# Altium schematic sheet Alimentation.SchDoc
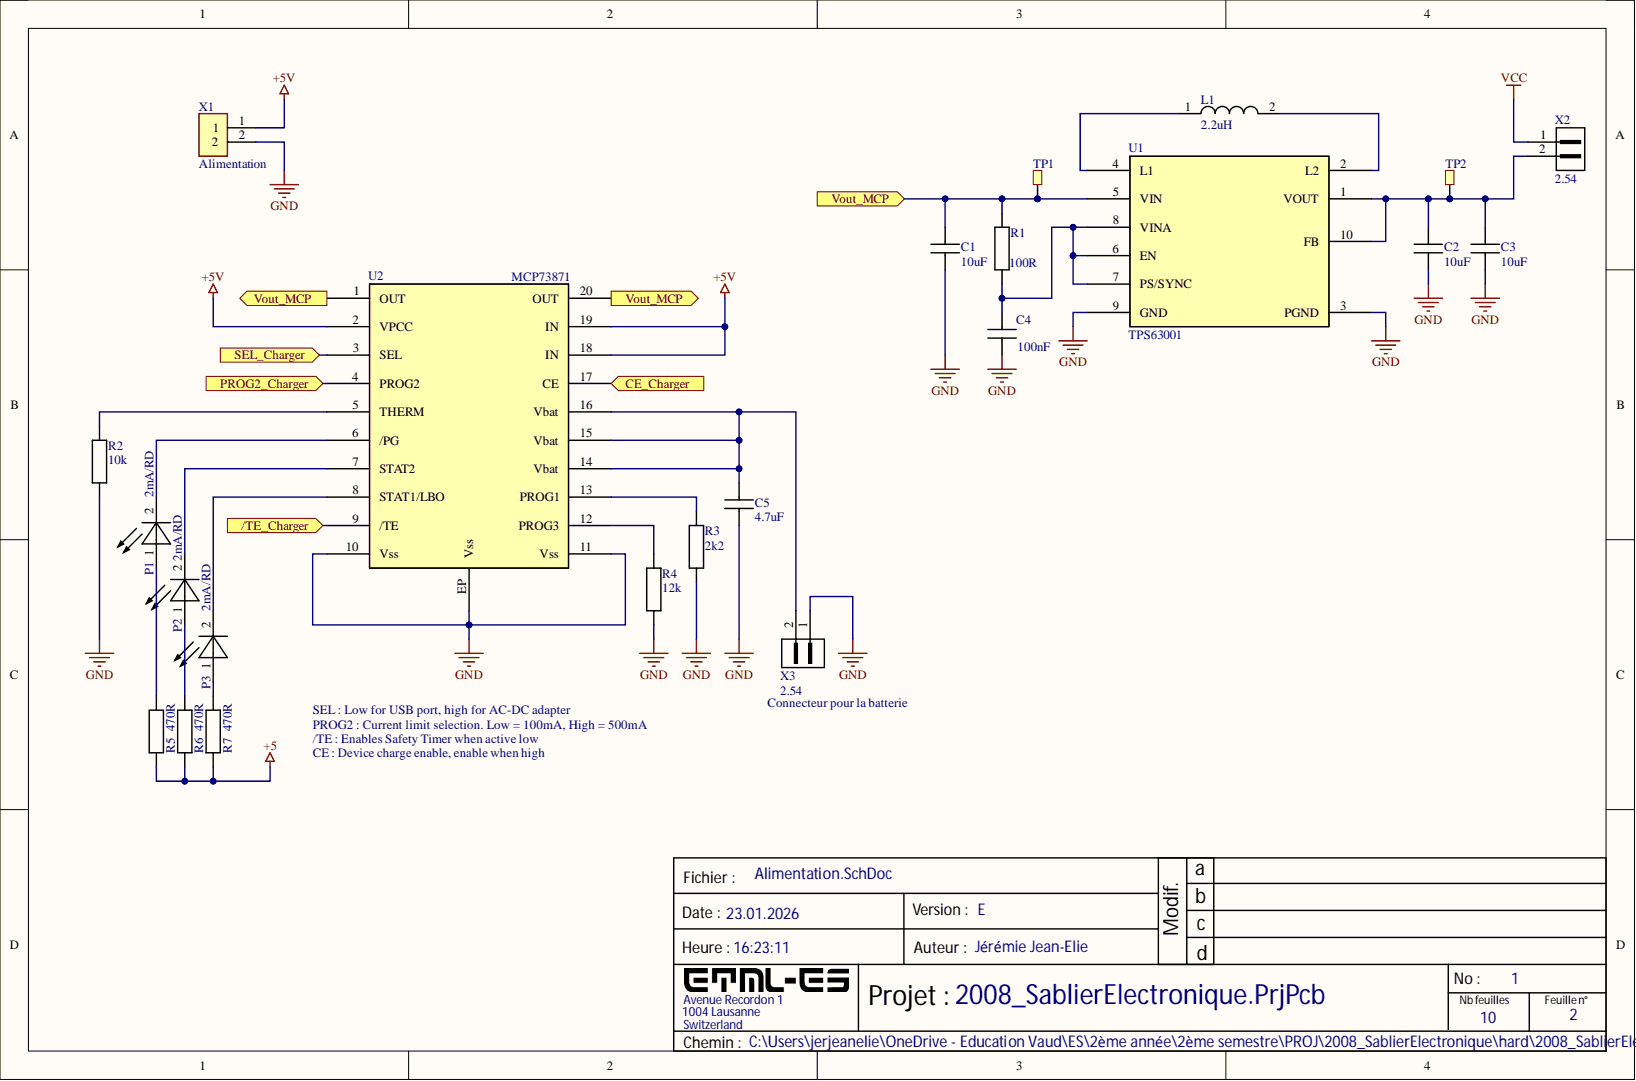
[diagram p0: Alimentation.SchDoc]

## Parts
C1  10uF  [CAPC1608X08M]
C2  10uF  [CAPC1608X08M]
C3  10uF  [CAPC1608X08M]
C4  100nF  [CAPC1608X08M]
C5  4.7uF  [CAPC1608X08M]
L1  2.2uH
P1  2mA/RD  [LED-PLCC2]
P2  2mA/RD  [LED-PLCC2]
P3  2mA/RD  [LED-PLCC2]
R1  100R  [RESC1608X06M]
R2  10k  [RESC1608X06M]
R3  2k2  [RESC1608X06M]
R4  12k  [RESC1608X06M]
R5  470R  [RESC1608X06M]
R6  470R  [RESC1608X06M]
R7  470R  [RESC1608X06M]
TP1  5019  [TESTPOINT_SMD]  (value not drawn)
TP2  5019  [TESTPOINT_SMD]  (value not drawn)
U1  TPS63001
U2  MCP73871
X1  Alimentation  [Header 2 pin]
X2  2.54  [PIN-1x02-2.54]
X3  2.54  [PIN-1x02-2.54]

## Ports
/TE_Charger, CE_Charger, PROG2_Charger, SEL_Charger, Vout_MCP

## Nets
+5: R5.1 R6.1 R7.1
+5V: U2.2(VPCC) U2.18(IN) U2.19(IN) X1.1
GND: C1.1 C2.1 C3.1 C4.1 C5.1 R2.1 R3.1 R4.1 U1.3(PGND) U1.9(GND) U2.10(Vss) U2.11(Vss) U2.EP(Vss) X1.2 X3.1
VCC: X2.1
/TE_Charger: U2.9(/TE) ; port /TE_Charger
CE_Charger: U2.17(CE) ; port CE_Charger
PROG2_Charger: U2.4(PROG2) ; port PROG2_Charger
SEL_Charger: U2.3(SEL) ; port SEL_Charger
Vout_MCP: C1.2 R1.2 TP1.1 U1.5(VIN) U2.1(OUT) U2.20(OUT) ; port Vout_MCP
NetC2_2: C2.2 C3.2 TP2.1 U1.1(VOUT) U1.10(FB) X2.2
NetC4_2: C4.2 R1.1 U1.6(EN) U1.7(PS/SYNC) U1.8(VINA)
NetC5_2: C5.2 U2.14(Vbat) U2.15(Vbat) U2.16(Vbat) X3.2
NetL1_1: L1.1 U1.4(L1)
NetL1_2: L1.2 U1.2(L2)
NetP1_1: P1.1 R5.2
NetP1_2: P1.2 U2.6(/PG)
NetP2_1: P2.1 R6.2
NetP2_2: P2.2 U2.7(STAT2)
NetP3_1: P3.1 R7.2
NetP3_2: P3.2 U2.8(STAT1/LBO)
NetR2_2: R2.2 U2.5(THERM)
NetR3_2: R3.2 U2.13(PROG1)
NetR4_2: R4.2 U2.12(PROG3)

## Library data of the parts
C1-C3 = CMP-065-000003-2: CAPC 10uF X5R 10V 20% 1608 (0603 INCH); Manufacturer: TDK; Manufacturer Number: C1608X5R1A106M080AC; IsStocked: 1; PN: PN-131657; TemperatureRange: -55°C to +85°C; Value: 10µF; Category: Passives; Component Kind: Standard; Dielectric: X5R; Library Name: ETML-ES; SubCategory: Condensateurs ceramique; Supplier 1: ETML; Supplier Part Number 1: FAB.0603_C 10uF; Supplier Price 1: 0.0944; Tolerance: ±20%; Voltage: 10V
C4 = CMP-065-000000-2: CAPC 100nF X7R 50V 10% 1608 (0603 INCH); Manufacturer: TDK; Manufacturer Number: CGA3E2X7R1H104K080AA; Current: NULL; IsStocked: 1; PN: PN-338083; TemperatureRange: -55°C to +125°C; Category: Passives; Component Kind: Standard; Dielectric: X7R; Library Name: ETML-ES; Power: NULL; SubCategory: Condensateurs ceramique; Supplier 1: ETML; Supplier Part Number 1: FAB.0603_C 100n; Supplier Price 1: 0.0100; TemperatureCoeff: NULL; Tolerance: ±10%; Value: 100nF; Voltage: 50V
C5 = CMP-065-000006-2: CAPC 4.7uF X5R 16V 10% 1608 (0603 INCH); Manufacturer: TDK; Manufacturer Number: C1608X5R1C475K080AC; IsStocked: 1; PN: PN-938781; TemperatureRange: -55°C to +85°C; Value: 4.7µF; Category: Passives; Component Kind: Standard; Dielectric: X5R; Library Name: ETML-ES; SubCategory: Condensateurs ceramique; Supplier 1: ETML; Supplier Part Number 1: FAB.0603_C 4.7uF; Supplier Price 1: 0.0453; Tolerance: ±10%; Voltage: 16V
P1-P3 = CMP-062-000009-1: LED 2 mA 2.2V 625nm Red PLCC2; Manufacturer: Vishay; Manufacturer Number: VLMT3100-GS08; Current: 2mA; IsStocked: True; PN: PN-800195; TemperatureRange: -40°C to +100°C; Category: Optoelectronics; Component Kind: Standard; Library Name: ETML-ES; SubCategory: Leds; Supplier 1: Farnell; Supplier Part Number 1: 2251489; Supplier Price 1: 0.179; Value: 2mA/RD; Voltage: 2.2V
R1 = CMP-066-000100-2: RES 100ohm  ±1% 0.1W  1608 (0603 INCH); Manufacturer: Yageo; Manufacturer Number: RC0603FR-07100RL; IsStocked: 1; PN: PN-739787; TemperatureCoeff: ±100ppm/°C; TemperatureRange: -55°C to 155°C; Value: 100; Voltage: 75V; Category: Passives; Component Kind: Standard; Library Name: ETML-ES; Power: 0.1W; SubCategory: Resistances couche epaisse; Supplier 1: ETML; Supplier Part Number 1: FAB.0603_R 100R; Supplier Price 1: 0.0200; Tolerance: ±1%
R2 = CMP-066-000124-2: RES 10kohm  ±1% 0.1W  1608 (0603 INCH); Manufacturer: Yageo; Manufacturer Number: RC0603FR-0710KL; IsStocked: 1; PN: PN-896786; TemperatureCoeff: ±100ppm/°C; TemperatureRange: -55°C to 155°C; Voltage: 75V; Category: Passives; Component Kind: Standard; Library Name: ETML-ES; Power: 0.1W; SubCategory: Resistances couche epaisse; Supplier 1: ETML; Supplier Part Number 1: FAB.0603_R 10k; Supplier Price 1: 0.0200; Tolerance: ±1%; Value: 10k
R3 = CMP-066-000116-2: RES 2.2kohm  ±1% 0.1W  1608 (0603 INCH); Manufacturer: Yageo; Manufacturer Number: RC0603FR-072K2L; IsStocked: 1; PN: PN-844453; TemperatureCoeff: ±100ppm/°C; TemperatureRange: -55°C to 155°C; Value: 2.2k; Voltage: 75V; Category: Passives; Component Kind: Standard; Library Name: ETML-ES; Power: 0.1W; SubCategory: Resistances couche epaisse; Supplier 1: ETML; Supplier Part Number 1: FAB.0603_R 2k2; Supplier Price 1: 0.0200; Tolerance: ±1%
R4 = CMP-066-000125-2: RES 12kohm  ±1% 0.1W  1608 (0603 INCH); Manufacturer: Yageo; Manufacturer Number: RC0603FR-0712KL; IsStocked: 1; PN: PN-565828; TemperatureCoeff: ±100ppm/°C; TemperatureRange: -55°C to 155°C; Voltage: 75V; Category: Passives; Component Kind: Standard; Library Name: ETML-ES; Power: 0.1W; SubCategory: Resistances couche epaisse; Supplier 1: ETML; Supplier Part Number 1: FAB.0603_R 12k; Supplier Price 1: 0.0200; Tolerance: ±1%; Value: 12k
R5-R7 = CMP-066-000108-2: RES 470ohm  ±1% 0.1W  1608 (0603 INCH); Manufacturer: Yageo; Manufacturer Number: RC0603FR-07470RL; IsStocked: 1; PN: PN-792120; TemperatureCoeff: ±100ppm/°C; TemperatureRange: -55°C to 155°C; Value: 470; Voltage: 75V; Category: Passives; Component Kind: Standard; Library Name: ETML-ES; Power: 0.1W; SubCategory: Resistances couche epaisse; Supplier 1: ETML; Supplier Part Number 1: FAB.0603_R 470R; Supplier Price 1: 0.0200; Tolerance: ±1%
TP1 TP2 = TESTPOINT: Test point smd; Component Kind: Standard; Supplier 1: Mouser; Supplier Part Number 1: 534-5019; Library Name: 2008_SablierElectronique_SCHLIB.SchLib
X1 = Header 2 pin: Connector Header Through Hole 2 position; MF: TE Connectivity; MP: 826632-1; Availability: Unavailable; Component Kind: Standard; DigiKey-Purchase-URL: https://snapeda.com/shop?store=DigiKey&id=2390280; EU_RoHS_Compliance: Compliant; Mouser-Purchase-URL: https://snapeda.com/shop?store=Mouser&id=2390280; Package: None; Price: None; SnapEDA_Link: https://www.snapeda.com/parts/826632-1/TE%20Connectivity%20AMP%20Connectors/view-part/2390280/?ref=snap; Library Name: 2008_SablierElectronique_SCHLIB.SchLib
X2 X3 = CMP-055-000006-1: PIN HEADER 2.54 1x02; Manufacturer: Würth Electronik; Manufacturer Number: 61300211121; Current: 3A; IsStocked: True; PN: PN-573903; TemperatureRange: -40°C to +105°C; Voltage: 250V; Category: Connectors; Component Kind: Standard; Library Name: ETML-ES; SubCategory: Pin Header; Supplier 1: ETML; Supplier Part Number 1: MAG.283.897; Supplier Price 1: 0.04; Value: 2.54
L1 = 2.2uH: Component Kind: Standard; Library Name: Schlib1.SchLib
U1 = TPS63001: Component Kind: Standard; Library Name: Schlib1.SchLib
U2 = MCP73871: Component Kind: Standard; Library Name: Schlib1.SchLib
